# Altium schematic sheet Connectors.SchDoc(Connectors)
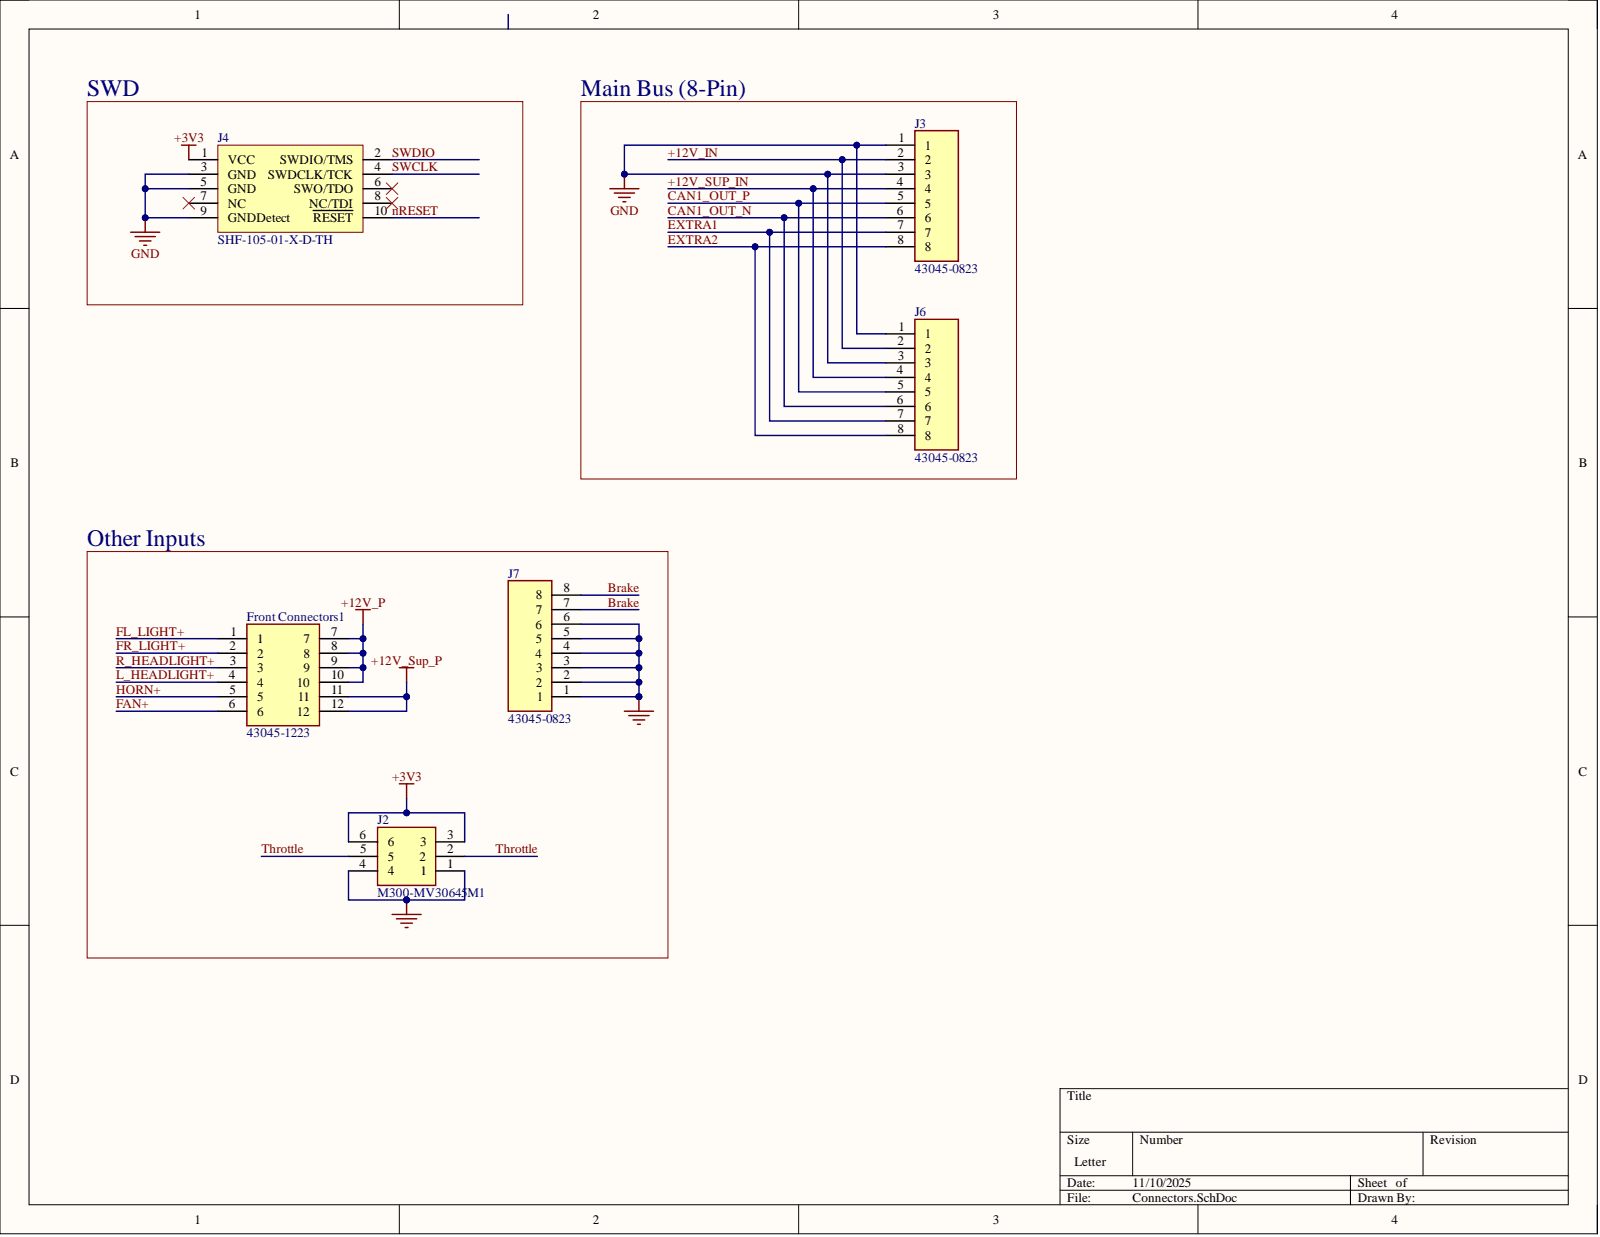
[diagram p0: Connectors.SchDoc]

## Parts
Front_Connectors1  43045-1223
J2  M300-MV30645M1
J3  43045-0823
J4  SHF-105-01-X-D-TH
J6  43045-0823
J7  43045-0823

## Nets
+3V3: J2.3 J2.6 J4.1(VCC)
+12V_P: Front_Connectors1.7 Front_Connectors1.8 Front_Connectors1.9 Front_Connectors1.10
+12V_Sup_P: Front_Connectors1.11 Front_Connectors1.12
GND: J2.1 J2.4 J3.1 J3.3 J4.3(GND) J4.5(GND) J4.9(GNDDetect) J6.1 J6.3 J7.1 J7.2 J7.3 J7.4 J7.5 J7.6
+12V_IN: J3.2 J6.2
+12V_SUP_IN: J3.4 J6.4
Brake: J7.7 J7.8
CAN1_OUT_N: J3.6 J6.6
CAN1_OUT_P: J3.5 J6.5
EXTRA1: J3.7 J6.7
EXTRA2: J3.8 J6.8
FAN+: Front_Connectors1.6
FL_LIGHT+: Front_Connectors1.1
FR_LIGHT+: Front_Connectors1.2
HORN+: Front_Connectors1.5
L_HEADLIGHT+: Front_Connectors1.4
nRESET: J4.10(RESET)
R_HEADLIGHT+: Front_Connectors1.3
SWCLK: J4.4(SWDCLK/TCK)
SWDIO: J4.2(SWDIO/TMS)
Throttle: J2.2 J2.5

Not connected: J4 6(SWO/TDO) 7(NC) 8(NC/TDI)

## Library data of the parts
Front_Connectors1 = CMP-002-00109-2: 12 Pos. Micro-Fit 3.0 Right-Angle Header; Manufacturer_Name: Molex; Component Kind: Standard; Datasheet Link: https://www.molex.com/pdm_docs/sd/430450221_sd.pdf; Design Item ID: 43045-1223; Height: 8.77mm; Manufacturer_Part_Number: 43045-1223; Mouser Part Number: 538-43045-1223; Mouser Price/Stock: https://www.mouser.co.uk/ProductDetail/Molex/43045-1223?qs=OWQJ9aScCGI4hIxSUDdLwA%3D%3D; Source: SamacSys.SchLib; Library Name: Solar Gators
J2 = CMP-002-00115-1: Headers & Wire Housings M300; Manufacturer_Name: Harwin; Component Kind: Standard; Datasheet Link: https://cdn.harwin.com/pdfs/M300-MV30645M1.pdf; Design Item ID: M300-MV30645M1; Height: 7mm; Manufacturer_Part_Number: M300-MV30645M1; Mouser Part Number: 855-M300-MV30645M1; Mouser Price/Stock: https://www.mouser.co.uk/ProductDetail/Harwin/M300-MV30645M1?qs=QkPn6D1Vh3iX8uB1YG8nVg%3D%3D; Source: SamacSys.SchLib; Library Name: Solar Gators
J3 J6 = CMP-002-00082-3: 8 Pos. Micro-Fit 3.0 Right-Angle Header; Manufacturer_Name: Molex; Component Kind: Standard; Datasheet Link: https://www.molex.com/pdm_docs/sd/430450221_sd.pdf; Design Item ID: 43045-0823; Height: 8.77mm; Manufacturer_Part_Number: 43045-0823; Mouser Part Number: 538-43045-0823; Mouser Price/Stock: https://www.mouser.co.uk/ProductDetail/Molex/43045-0823?qs=pWIReIhcJCRuGea0TEKJ2Q%3D%3D; Pins: 8; Source: SamacSys.SchLib; Library Name: Solar Gators
J4 = CMP-002-00085-5: JTAG 10-Pin Header 1.27mm; MF: Samtec; Availability: Unavailable; Case/Package: None; Component Kind: Standard; Component Type: Standard; Design Item ID: SHF-105-01-X-D-TH; MP: SHF-105-01-X-D-TH; Pins: 10; Price: None; Source: SHF-105-01-X-D-TH.SchLib; Library Name: Solar Gators
J7 = CMP-002-00082-2: Micro-Fit 3.0 8-Pos Right-Angle Header; Manufacturer_Name: Molex; Component Kind: Standard; Datasheet Link: https://www.molex.com/pdm_docs/sd/430450221_sd.pdf; Design Item ID: 43045-0823; Height: 8.77mm; Manufacturer_Part_Number: 43045-0823; Mouser Part Number: 538-43045-0823; Mouser Price/Stock: https://www.mouser.co.uk/ProductDetail/Molex/43045-0823?qs=pWIReIhcJCRuGea0TEKJ2Q%3D%3D; Pins: 8; Source: SamacSys.SchLib; Library Name: Solar Gators
